# Altium schematic sheet Gate Driver Board.SchDoc("Gate Driver Board")
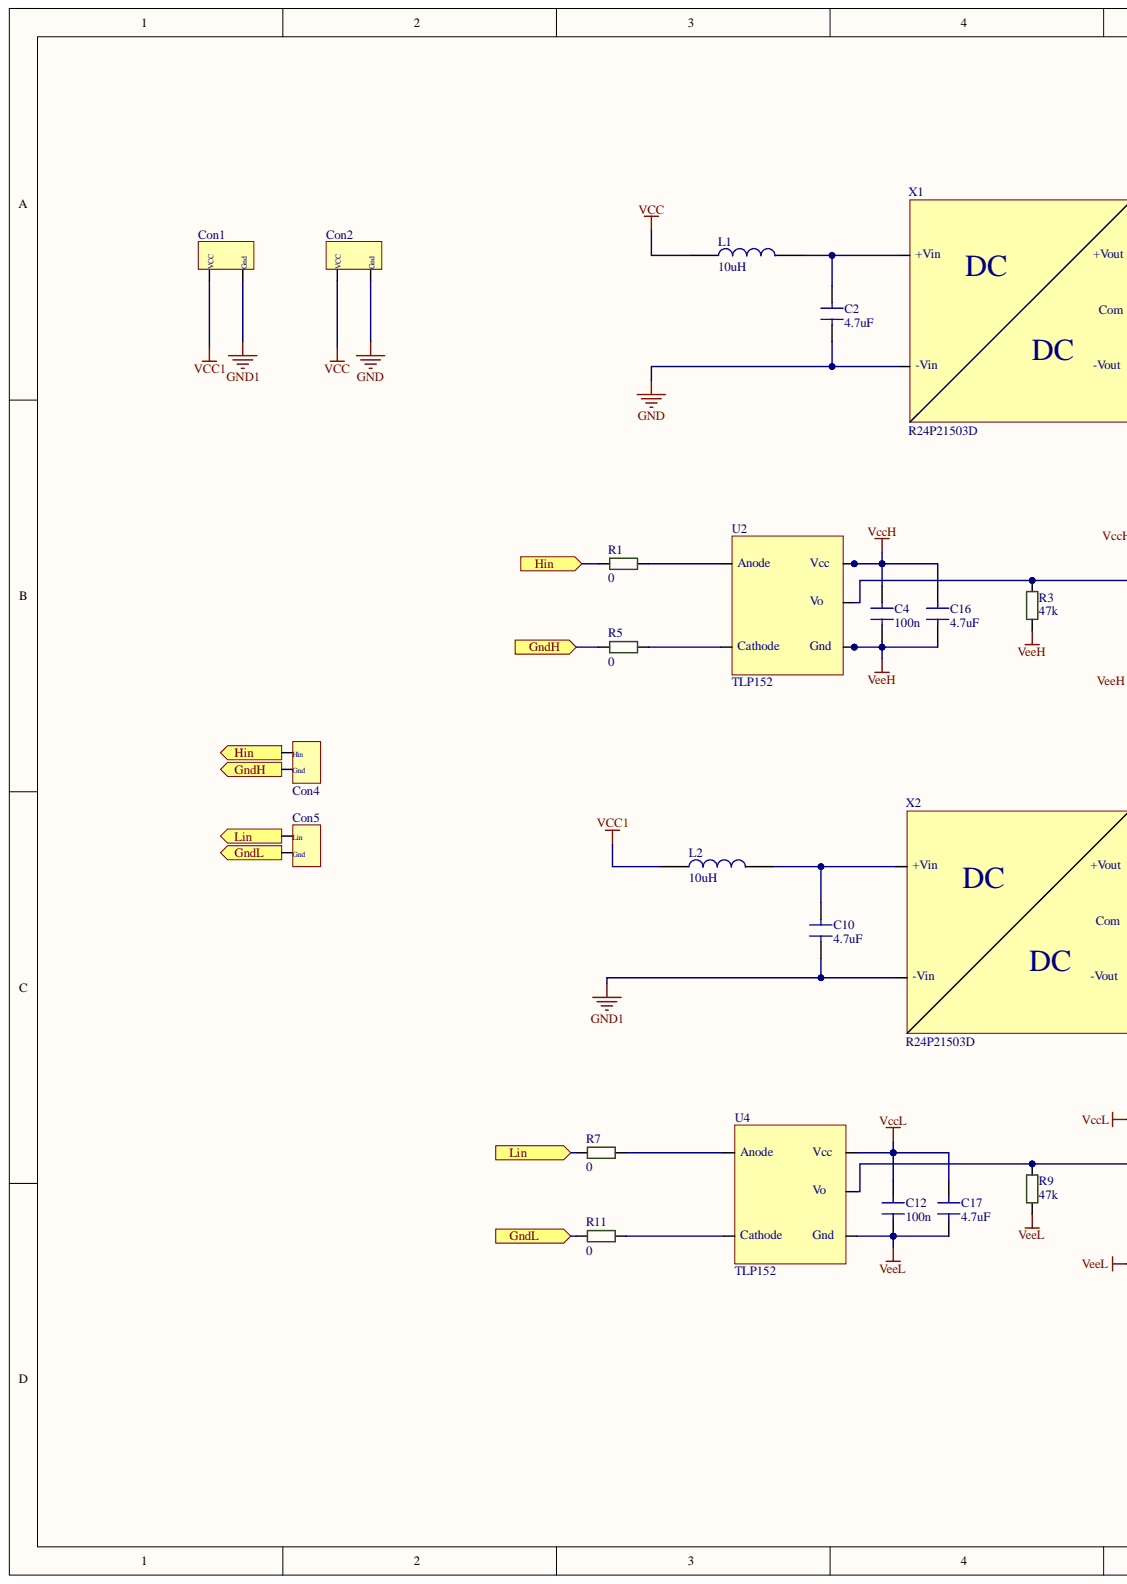
[diagram p0: Gate Driver Board.SchDoc, left]
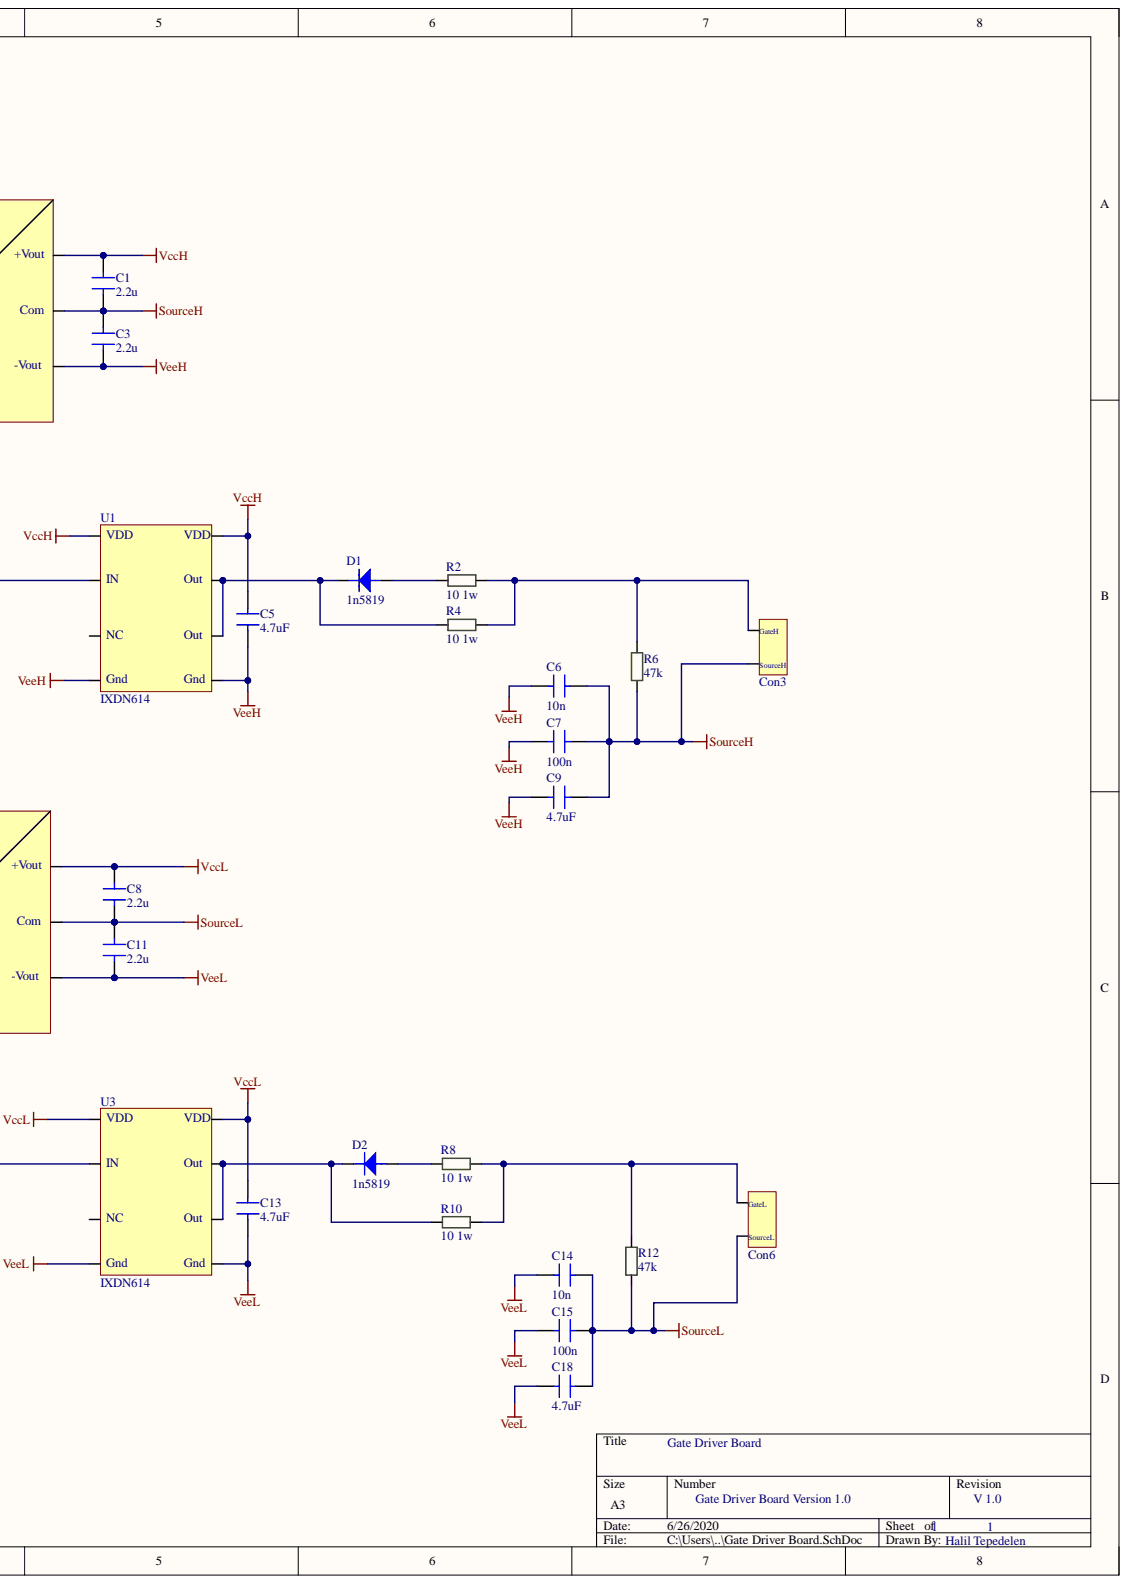
[diagram p1: Gate Driver Board.SchDoc, right]

## Parts
C1  2.2u  [C-0805]
C2  4.7uF  [C-1206]
C3  2.2u  [C-0805]
C4  100n  [C-0805]
C5  4.7uF  [C-1206]
C6  10n  [C-0805]
C7  100n  [C-0805]
C8  2.2u  [C-0805]
C9  4.7uF  [C-1206]
C10  4.7uF  [C-1206]
C11  2.2u  [C-0805]
C12  100n  [C-0805]
C13  4.7uF  [C-1206]
C14  10n  [C-0805]
C15  100n  [C-0805]
C16  4.7uF  [C-1206]
C17  4.7uF  [C-1206]
C18  4.7uF  [C-1206]
Con1  Connector  [Conn]  (value not drawn)
Con2  Connector  [Conn]  (value not drawn)
Con3  Connector2_1  [Conn]  (value not drawn)
Con4  Connector2  [Conn]  (value not drawn)
Con5  Connector3  [Conn]  (value not drawn)
Con6  Connector2_2  [Conn]  (value not drawn)
D1  1n5819  [SOD123]
D2  1n5819  [SOD123]
L1  10uH  [WE-TPC_282892]
L2  10uH  [WE-TPC_282892]
R1  0  [R-0805]
R2  10 1w  [R-2512]
R3  47k  [R-0805]
R4  10 1w  [R-2512]
R5  0  [R-0805]
R6  47k  [R-0805]
R7  0  [R-0805]
R8  10 1w  [R-2512]
R9  47k  [R-0805]
R10  10 1w  [R-2512]
R11  0  [R-0805]
R12  47k  [R-0805]
U1  IXDN614  [FAN3122 Soic8]
U2  TLP152  [TLP152]
U3  IXDN614  [FAN3122 Soic8]
U4  TLP152  [TLP152]
X1  R24P21503D  [SIP7]
X2  R24P21503D  [SIP7]

## Ports
GndH, GndL, Hin, Lin

## Nets
GND: C2.1 Con2.2 X1.2(-Vin)
GND1: C10.1 Con1.2 X2.2(-Vin)
SourceH: C1.1 C3.2 C6.1 C7.1 C9.2 Con3.2 R6.1 X1.6(Com)
SourceL: C8.1 C11.2 C14.1 C15.1 C18.2 Con6.2 R12.1 X2.6(Com)
VCC: Con2.1 L1.1
VCC1: Con1.1 L2.1
VccH: C1.2 C4.2 C5.2 C16.2 U1.1(VDD) U1.8(VDD) U2.5(Vcc) X1.7(+Vout)
VccL: C8.2 C12.2 C13.2 C17.2 U3.1(VDD) U3.8(VDD) U4.5(Vcc) X2.7(+Vout)
VeeH: C3.1 C4.1 C5.1 C6.2 C7.2 C9.1 C16.1 R3.1 U1.4(Gnd) U1.5(Gnd) U2.3(Gnd) X1.5(-Vout)
VeeL: C11.1 C12.1 C13.1 C14.2 C15.2 C17.1 C18.1 R9.1 U3.4(Gnd) U3.5(Gnd) U4.3(Gnd) X2.5(-Vout)
GndH: Con4.2 R5.1 ; port GndH
GndL: Con5.2 R11.1 ; port GndL
Hin: Con4.1 R1.1 ; port Hin
Lin: Con5.1 R7.1 ; port Lin
NetC2_2: C2.2 L1.2 X1.1(+Vin)
NetC10_2: C10.2 L2.2 X2.1(+Vin)
NetCon3_1: Con3.1 R2.2 R4.2 R6.2
NetCon6_1: Con6.1 R8.2 R10.2 R12.2
NetD1_a: D1.a R2.1
NetD1_k: D1.k R4.1 U1.6(Out) U1.7(Out)
NetD2_a: D2.a R8.1
NetD2_k: D2.k R10.1 U3.6(Out) U3.7(Out)
NetR1_2: R1.2 U2.1(Anode)
NetR3_2: R3.2 U1.2(IN) U2.4(Vo)
NetR5_2: R5.2 U2.2(Cathode)
NetR7_2: R7.2 U4.1(Anode)
NetR9_2: R9.2 U3.2(IN) U4.4(Vo)
NetR11_2: R11.2 U4.2(Cathode)

Not connected: U1 3(NC); U3 3(NC)

## Library data of the parts
C1 C3 C8 C11 = C-0805: MLCC (2012) 0805 2.2uF 50VDC ±10% X5R; Manufacturer: SAMSUNG; Özdisan Part Number: CL21A225KBQNNNE; Component Kind: Standard; Library Name: Gate Driver Board.SchLib; Link: https://www.ozdisan.com/pasif-komponentler/kondansatorler/smd-kondansatorler-mlcc/CL21A225KBQNNNE; Price: 0,04880
C2 C9 C10 C18 = Cap1206: MLCC (3216) 1206 4.7uF 50VDC ±10% X7R; Manufacturer: SAMSUNG; Özdisan Part Number: CL31B475KBHNNNE; Component Kind: Standard; Library Name: Gate Driver Board.SchLib; Link: https://www.ozdisan.com/pasif-komponentler/kondansatorler/smd-kondansatorler-mlcc/CL31B475KBHNNNE; Price: 0,10407
C4 = C-0805: MLCC (2012) 0805 100nF 50VDC ±10% X7RMLCC (2012) 0805 100nF 50VDC ±10% X7R; Manufacturer: SAMSUNG; Özdisan Part Number: CL21B104KBCNNNC; Component Kind: Standard; Library Name: Gate Driver Board.SchLib; Link: https://www.ozdisan.com/pasif-komponentler/kondansatorler/smd-kondansatorler-mlcc/CL21B104KBCNNNC; Price: 0,00844
C5 C13 C16 C17 = C-1206: MLCC (3216) 1206 4.7uF 50VDC ±10% X7R; Manufacturer: SAMSUNG; Özdisan Part Number: CL31B475KBHNNNE; Component Kind: Standard; Library Name: Gate Driver Board.SchLib; Link: https://www.ozdisan.com/pasif-komponentler/kondansatorler/smd-kondansatorler-mlcc/CL31B475KBHNNNE; Price: 0,10407
C6 C14 = C-0805: MLCC (2012) 0805 10nF 50VDC ±10% X7R; Manufacturer: SAMSUNG; Özdisan Part Number: CL21B103KBANNNL; Component Kind: Standard; Library Name: Gate Driver Board.SchLib; Link: https://www.ozdisan.com/pasif-komponentler/kondansatorler/smd-kondansatorler-mlcc/CL21B103KBANNNL; Price: 0,01257
C7 C12 C15 = C-0805: MLCC (2012) 0805 100nF 50VDC ±10% X7R; Manufacturer: SAMSUNG; Özdisan Part Number: CL21B104KBCNNNC; Component Kind: Standard; Library Name: Gate Driver Board.SchLib; Link: https://www.ozdisan.com/pasif-komponentler/kondansatorler/smd-kondansatorler-mlcc/CL21B104KBCNNNC; Price: 0,00844
Con1 Con2 = Connector: PIN HEADER 40PIN(1x40) 2.54mm THT V/T; Manufacturer: KLS ELECTRONIC; Özdisan Part Number: KLS1-207-1-40-S-3X9.5X15; Component Kind: Standard; Library Name: Gate Driver Board.SchLib; Link: https://www.ozdisan.com/konnektor-baglanti-elemanlari/headers/pin-header/KLS1-207-1-40-S-3X9-5X15; Price: 0,17227
Con3 = Connector2_1: PIN HEADER 40PIN(1x40) 2.54mm THT V/T; Manufacturer: KLS ELECTRONIC; Özdisan Part Number: KLS1-207-1-40-S-3X9.5X15; Component Kind: Standard; Library Name: Gate Driver Board.SchLib; Link: https://www.ozdisan.com/konnektor-baglanti-elemanlari/headers/pin-header/KLS1-207-1-40-S-3X9-5X15; Price: 0,17227
Con4 = Connector2: PIN HEADER 40PIN(1x40) 2.54mm THT V/T; Manufacturer: KLS ELECTRONIC; Özdisan Part Number: KLS1-207-1-40-S-3X9.5X15; Component Kind: Standard; Library Name: Gate Driver Board.SchLib; Link: https://www.ozdisan.com/konnektor-baglanti-elemanlari/headers/pin-header/KLS1-207-1-40-S-3X9-5X15; Price: 0,17227
Con5 = Connector3: PIN HEADER 40PIN(1x40) 2.54mm THT V/T; Manufacturer: KLS ELECTRONIC; Özdisan Part Number: KLS1-207-1-40-S-3X9.5X15; Component Kind: Standard; Library Name: Gate Driver Board.SchLib; Link: https://www.ozdisan.com/konnektor-baglanti-elemanlari/headers/pin-header/KLS1-207-1-40-S-3X9-5X15; Price: 0,17227
Con6 = Connector2_2: PIN HEADER 40PIN(1x40) 2.54mm THT V/T; Manufacturer: KLS ELECTRONIC; Özdisan Part Number: KLS1-207-1-40-S-3X9.5X15; Component Kind: Standard; Library Name: Gate Driver Board.SchLib; Link: https://www.ozdisan.com/konnektor-baglanti-elemanlari/headers/pin-header/KLS1-207-1-40-S-3X9-5X15; Price: 0,17227
D1 = 1N4148W-E3-18: DIODE SCHOTTKY 1A 40V SOD123; Manufacturer: HOTTECH; Özdisan Part Number: B5819W; Component Kind: Standard; ComponentLink1Description: https://datasheet.ciiva.com/29885/1n4148w-29885941.pdf?src-supplier=Avnet; ComponentLink1URL: https://datasheet.ciiva.com/29885/1n4148w-29885941.pdf?src-supplier=Avnet; ComponentLink2Description: https://datasheet.ciiva.com/29593/1n4148w-29593113.pdf?src-supplier=Digi-Key; ComponentLink2URL: https://datasheet.ciiva.com/29593/1n4148w-29593113.pdf?src-supplier=Digi-Key; ComponentLink3Description: https://datasheet.ciiva.com/29593/1n4148w-29593111.pdf?src-supplier=Digi-Key; ComponentLink3URL: https://datasheet.ciiva.com/29593/1n4148w-29593111.pdf?src-supplier=Digi-Key; ComponentLink4Description: https://datasheet.ciiva.com/29593/1n4148w-29593112.pdf?src-supplier=Digi-Key; ComponentLink4URL: https://datasheet.ciiva.com/29593/1n4148w-29593112.pdf?src-supplier=Digi-Key; Library Name: Gate Driver Board.SchLib; Link: https://www.ozdisan.com/guc-yari-iletkenleri/diyot-modul-diyot-dogrultucular/schottky-diyotlar/B5819W; Price: 0,04258
D2 = 1N4148W-E3-18: Diode Small Signal Switching 100V 0.15A 2-Pin SOD-123 T/R; Manufacturer: HOTTECH; Özdisan Part Number: B5819W; Component Kind: Standard; ComponentLink1Description: https://datasheet.ciiva.com/29885/1n4148w-29885941.pdf?src-supplier=Avnet; ComponentLink1URL: https://datasheet.ciiva.com/29885/1n4148w-29885941.pdf?src-supplier=Avnet; ComponentLink2Description: https://datasheet.ciiva.com/29593/1n4148w-29593113.pdf?src-supplier=Digi-Key; ComponentLink2URL: https://datasheet.ciiva.com/29593/1n4148w-29593113.pdf?src-supplier=Digi-Key; ComponentLink3Description: https://datasheet.ciiva.com/29593/1n4148w-29593111.pdf?src-supplier=Digi-Key; ComponentLink3URL: https://datasheet.ciiva.com/29593/1n4148w-29593111.pdf?src-supplier=Digi-Key; ComponentLink4Description: https://datasheet.ciiva.com/29593/1n4148w-29593112.pdf?src-supplier=Digi-Key; ComponentLink4URL: https://datasheet.ciiva.com/29593/1n4148w-29593112.pdf?src-supplier=Digi-Key; Library Name: Gate Driver Board.SchLib; Link: https://www.ozdisan.com/guc-yari-iletkenleri/diyot-modul-diyot-dogrultucular/schottky-diyotlar/B5819W; Price: 0,04258
L1 L2 = inductor: INDUCTOR POWER 10UH 2.8X2.8X2.8MM SMD; Manufacturer: WURTH; Özdisan Part Number: 744025100; Component Kind: Standard; Library Name: Gate Driver Board.SchLib; Link: https://www.ozdisan.com/pasif-komponentler/induktorler/sabit-induktor/744025100; Price: 0,74325
R1 R5 R7 R11 = Res0805: RES.(2012) 0805 0 Ohms 5% 1/8W-S 800PPM; Manufacturer: ROYALOHM; Özdisan Part Number: 0805S8J0000T5E; Component Kind: Standard; Library Name: Gate Driver Board.SchLib; Link: https://www.ozdisan.com/pasif-komponentler/direncler/smt-smd-direncler/0805S8J0000T5E; Price: 0,00234
R2 R4 R8 R10 = R-2512: RES.(6432) 2512 5.1 Ohms 5% 1W 400PPM; Manufacturer: ROYALOHM; Özdisan Part Number: 25121WJ051JT4E; Component Kind: Standard; Library Name: Gate Driver Board.SchLib; Link: https://www.ozdisan.com/pasif-komponentler/direncler/smt-smd-direncler/25121WJ051JT4E; Price: 0,02294
R3 R6 R9 R12 = Res0805: RES.(2012) 0805 47K Ohms 5% 1/8W-S 100PPM; Manufacturer: ROYALOHM; Özdisan Part Number: 0805S8J0473T5E; Component Kind: Standard; Library Name: Gate Driver Board.SchLib; Link: https://www.ozdisan.com/pasif-komponentler/direncler/smt-smd-direncler/0805S8J0473T5E; Price: 0,00234
U1 U3 = IXDN614SITR: IC-614 DRIVER MOSFET/IGBT SOIC8 IXYS CLARE; Component Kind: Standard; ComponentLink1Description: https://datasheet.ciiva.com/6613/ixd-614-6613145.pdf?src-supplier=Digi-Key; ComponentLink1URL: https://datasheet.ciiva.com/6613/ixd-614-6613145.pdf?src-supplier=Digi-Key; Library Name: Gate Driver Board.SchLib; Link: https://www.ozdisan.com/entegre-devreler-ics/guc-entegreleri/ic-guc-surucu-entegreleri/IXDN614SI; Manufacturer: IXYS CLARE; Price: 3,90467; Özdisan Part Number: IXDN614SI
U2 U4 = TLP152(TPL,E: IC-152 PHOTOCOUPLER GATE DRIVE SO6 TOSHIBA; Component Kind: Standard; ComponentLink1Description: https://datasheet.ciiva.com/41848/tlp152-datasheet-en-20120914-41848928.pdf?src-supplier=Digi-Key; ComponentLink1URL: https://datasheet.ciiva.com/41848/tlp152-datasheet-en-20120914-41848928.pdf?src-supplier=Digi-Key; Library Name: Gate Driver Board.SchLib; Link: https://www.ozdisan.com/optolar-sensorler/optolar/gate-surucu-optokuplorleri/TLP152TPLE; Manufacturer: TOSHIBA; Price: 0,80155; Özdisan Part Number: TLP152(TPL,E
X1 = R24P21503D: DC/DC CONV 2W 12VDC +15/-3VDC 93mA/-185mA SIP7; Manufacturer: RECOM; Özdisan Part Number: R12P21503D; Component Kind: Standard; Library Name: Gate Driver Board.SchLib; Link: https://www.ozdisan.com/guc-kaynaklari-adaptorler/izole-donusturucu-moduller/dcdc-donusturuculer/R12P21503D; Price: 11,27149
X2 = R24P21503D: DC/DC CONV 2W 12VDC +15/-3VDC 93mA/-185mA SIP7; Manufacturer: RECOM; Özdisan Part NUMBER: R12P21503D; Component Kind: Standard; Library Name: Gate Driver Board.SchLib; Link: https://www.ozdisan.com/guc-kaynaklari-adaptorler/izole-donusturucu-moduller/dcdc-donusturuculer/R12P21503D; Price: 11,27149
